# Altium schematic sheet Sheet1.SchDoc
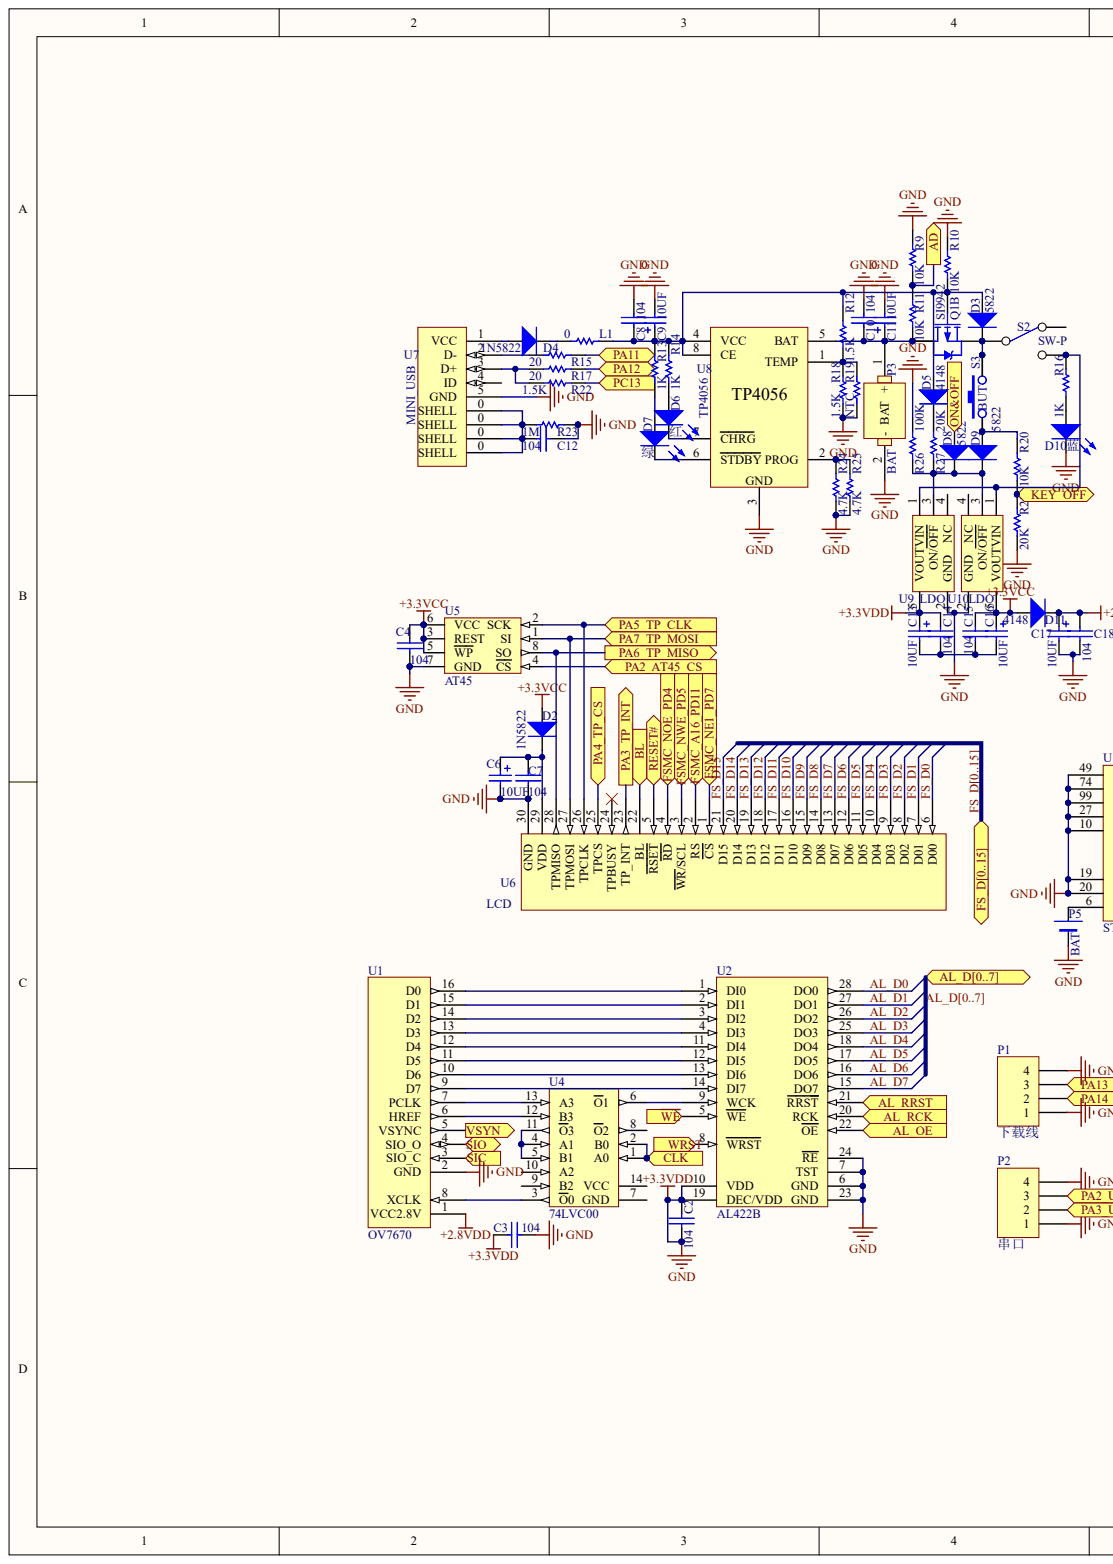
[diagram p0: Sheet1.SchDoc, left]
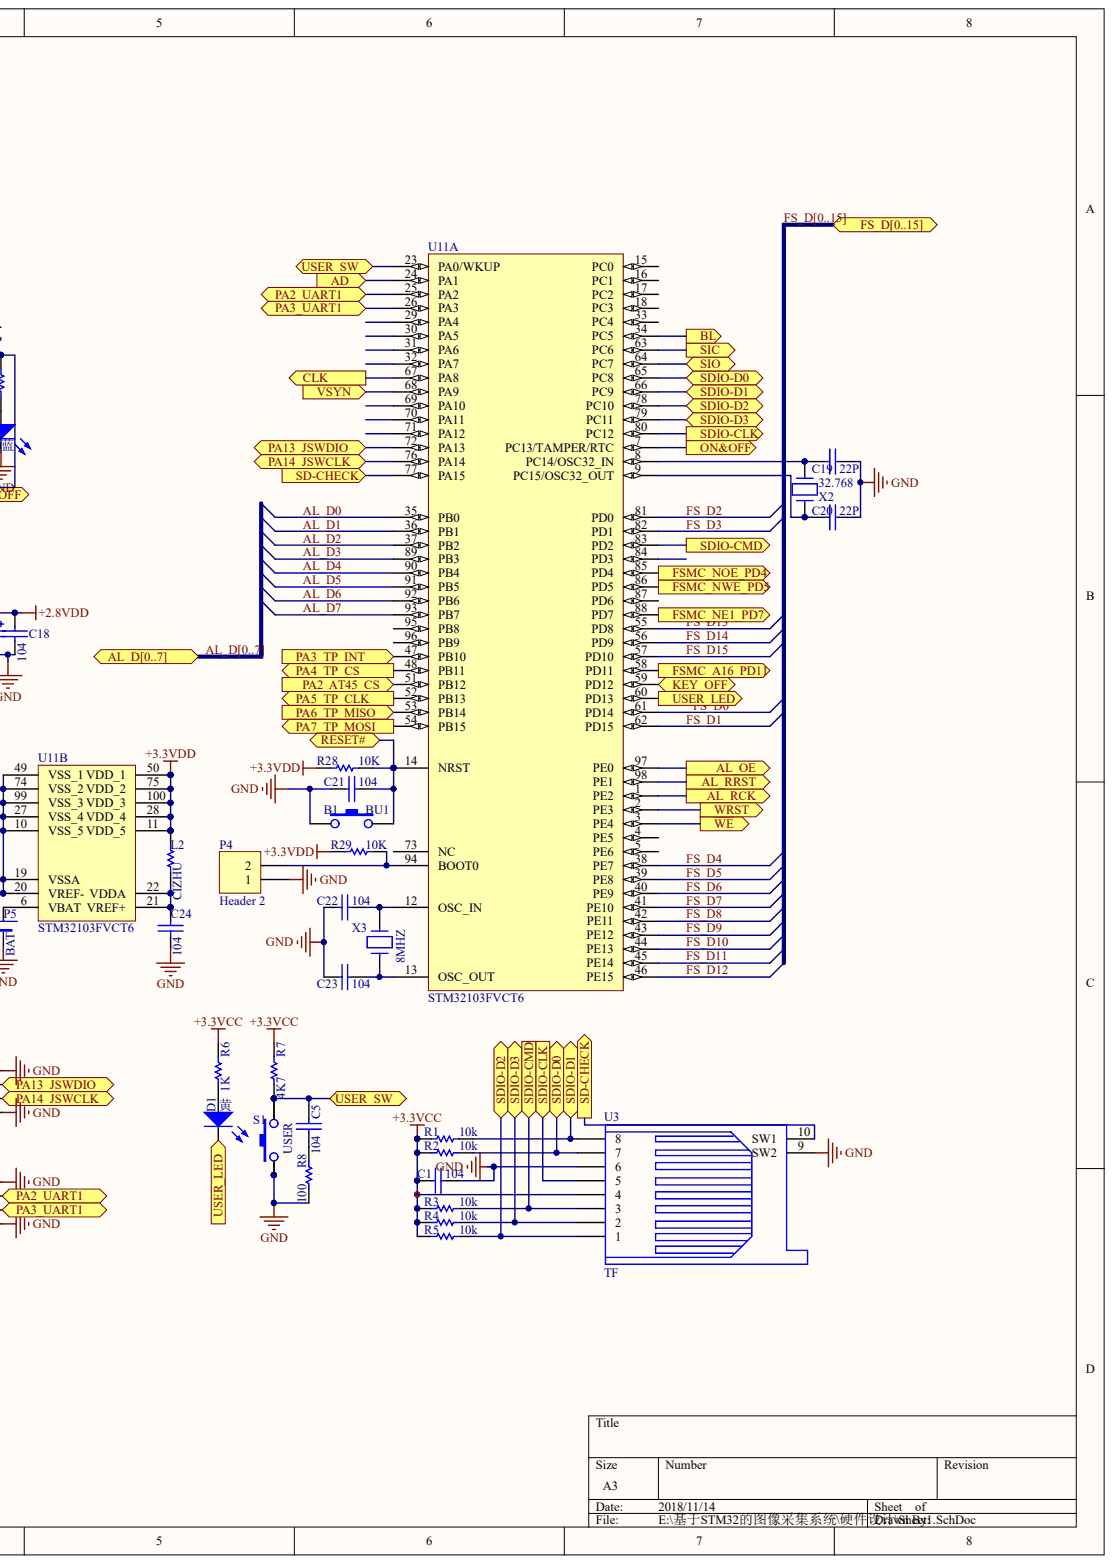
[diagram p1: Sheet1.SchDoc, right]

## Parts
B1  BU1
C1  104
C2  104
C3  104
C4  104
C5  104
C6  10UF
C7  104
C8  104
C9  10UF
C10  104
C11  10UF
C12  104
C13  10UF
C14  104
C15  10UF
C16  104
C17  10UF
C18  104
C19  22P
C20  22P
C21  104
C22  104
C23  104
C24  104
D1  黄
D2  1N5822
D3  5822
D4  1N5822
D5  4148
D6  红
D7  绿
D8  5822
D9  5822
D10  蓝
D11  4148
L1  0
L2  CIZHU
P1  下载线
P2  串口
P3  BAT
P4  Header 2
P5  BAT
Q1  SI9942  (part B)
R1  10k
R2  10k
R3  10k
R4  10k
R5  10k
R6  1K
R7  4K7
R8  100
R9  10K
R10  10K
R11  10K
R12  1.5K
R13  1K
R14  1K
R15  20
R16  1K
R17  20
R18  1.5K
R19  NTC
R20  10K
R21  20K
R22  1.5K
R23  1M
R24  4.7K
R25  4.7K
R26  100K
R27  20K
R28  10K
R29  10K
S1  USER
S2  SW-P
S3  BUT
U1  OV7670
U2  AL422B
U3  TF
U4  74LVC00
U5  AT45
U6  LCD
U7  MINI_USB
U8  TP4056
U9  LDO
U10  LDO
U11  STM32103FVCT6  (parts A,B)
X2  32.768
X3  8MHZ

## Ports
AD, AL_D0, AL_D1, AL_D2, AL_D3, AL_D4, AL_D5, AL_D6, AL_D7, AL_D[0..7], AL_OE, AL_RCK, AL_RRST, BL, CLK, FS_D0, FS_D1, FS_D2, FS_D3, FS_D4, FS_D5, FS_D6, FS_D7, FS_D8, FS_D9, FS_D10, FS_D11, FS_D12, FS_D13, FS_D14, FS_D15, FS_D[0..15], FSMC_A16_PD11, FSMC_NE1_PD7, FSMC_NOE_PD4, FSMC_NWE_PD5, KEY_OFF, ON&OFF, PA2_AT45_CS, PA2_UART1, PA3_TP_INT, PA3_UART1, PA4_TP_CS, PA5_TP_CLK, PA6_TP_MISO, PA7_TP_MOSI, PA11, PA12, PA13_JSWDIO, PA14_JSWCLK, PC13, RESET#, SD-CHECK, SDIO-CLK, SDIO-CMD, SDIO-D0, SDIO-D1, SDIO-D2, SDIO-D3, SIC, SIO, USER_LED, USER_SW, VSYN, WRST, ~{WE}

## Nets
+2.8VDD: C17.1 C18.2 D11.2 U1.1(VCC2.8V)
+3.3VCC: C1.1 C4.2 C15.1 C16.2 D2.1 D11.1 R1.2 R2.2 R3.2 R4.2 R5.2 R6.2 R7.2 U3.4 U5.3(REST) U5.5(WP) U5.6(VCC) U10.5(VOUT)
+3.3VDD: B1.1 C1.2 C2.1 C2.2 C3.1 C3.2 C4.1 C6.2 C7.1 C8.2 C9.2 C10.1 C11.2 C12.2 C13.1 C14.2 C17.2 C18.1 C19.2 C20.2 C21.1 C22.1 C23.1 C24.1 D10.2 L2.1 P1.1 P1.4 P2.1 P2.4 P3.2 P4.1 P5.2 R8.1 R9.1 R10.2 R18.2 R19.2 R21.2 R23.1 R24.2 R25.2 R26.2 R28.1 R29.1 S1.1 S1.2 U1.2(GND) U2.6(GND) U2.7(TST) U2.10(VDD) U2.19(DEC/VDD) U2.23(GND) U2.24(RE) U3.6 U3.9(SW2) U5.7(GND) U6.30(GND) U7.5(GND) U8.3 U9.2(GND) U9.5(VOUT) U10.2(GND) U11.10(VSS_5) U11.11(VDD_5) U11.19(VSSA) U11.20(VREF-) U11.27(VSS_4) U11.28(VDD_4) U11.49(VSS_1) U11.50(VDD_1) U11.74(VSS_2) U11.75(VDD_2) U11.99(VSS_3) U11.100(VDD_3)
AD: R9.2 R11.1 U11.24(PA1) ; port AD
AL_D0: U2.28(DO0) U11.35(PB0) ; port AL_D[0..7]  [also labelled AL_D]
AL_D1: U2.27(DO1) U11.36(PB1) ; port AL_D[0..7]  [also labelled AL_D]
AL_D2: U2.26(DO2) U11.37(PB2) ; port AL_D[0..7]  [also labelled AL_D]
AL_D3: U2.25(DO3) U11.89(PB3) ; port AL_D[0..7]  [also labelled AL_D]
AL_D4: U2.18(DO4) U11.90(PB4) ; port AL_D[0..7]  [also labelled AL_D]
AL_D5: U2.17(DO5) U11.91(PB5) ; port AL_D[0..7]  [also labelled AL_D]
AL_D6: U2.16(DO6) U11.92(PB6) ; port AL_D[0..7]  [also labelled AL_D]
AL_D7: U2.15(DO7) U11.93(PB7) ; port AL_D[0..7]  [also labelled AL_D]
AL_OE: U2.22(OE) U11.97(PE0) ; port AL_OE
AL_RCK: U2.20(RCK) U11.1(PE2) ; port AL_RCK
AL_RRST: U2.21(RRST) U11.98(PE1) ; port AL_RRST
BL: U6.22(BL) U11.34(PC5) ; port BL
CLK: U4.1(A0) U4.2(B0) U11.67(PA8) ; port CLK
FS_D0: U6.6(D00) U11.61(PD14) ; port FS_D[0..15]  [also labelled FS_D]
FS_D1: U6.7(D01) U11.62(PD15) ; port FS_D[0..15]  [also labelled FS_D]
FS_D2: U6.8(D02) U11.81(PD0) ; port FS_D[0..15]  [also labelled FS_D]
FS_D3: U6.9(D03) U11.82(PD1) ; port FS_D[0..15]  [also labelled FS_D]
FS_D4: U6.10(D04) U11.38(PE7) ; port FS_D[0..15]  [also labelled FS_D]
FS_D5: U6.11(D05) U11.39(PE8) ; port FS_D[0..15]  [also labelled FS_D]
FS_D6: U6.12(D06) U11.40(PE9) ; port FS_D[0..15]  [also labelled FS_D]
FS_D7: U6.13(D07) U11.41(PE10) ; port FS_D[0..15]  [also labelled FS_D]
FS_D8: U6.14(D08) U11.42(PE11) ; port FS_D[0..15]  [also labelled FS_D]
FS_D9: U6.15(D09) U11.43(PE12) ; port FS_D[0..15]  [also labelled FS_D]
FS_D10: U6.16(D10) U11.44(PE13) ; port FS_D[0..15]  [also labelled FS_D]
FS_D11: U6.17(D11) U11.45(PE14) ; port FS_D[0..15]  [also labelled FS_D]
FS_D12: U6.18(D12) U11.46(PE15) ; port FS_D[0..15]  [also labelled FS_D]
FS_D13: U6.19(D13) U11.55(PD8) ; port FS_D[0..15]  [also labelled FS_D]
FS_D14: U6.20(D14) U11.56(PD9) ; port FS_D[0..15]  [also labelled FS_D]
FS_D15: U6.21(D15) U11.57(PD10) ; port FS_D[0..15]  [also labelled FS_D]
FSMC_A16_PD11: U6.2(RS) U11.58(PD11) ; port FSMC_A16_PD11
FSMC_NE1_PD7: U6.1(CS) U11.88(PD7) ; port FSMC_NE1_PD7
FSMC_NOE_PD4: U6.4(RD) U11.85(PD4) ; port FSMC_NOE_PD4
FSMC_NWE_PD5: U6.3(WR/SCL) U11.86(PD5) ; port FSMC_NWE_PD5
KEY_OFF: R20.2 R21.1 U11.59(PD12) ; port KEY_OFF
ON&OFF: D8.1 U11.7(PC13/TAMPER/RTC) ; port ON&OFF
PA2_AT45_CS: U5.4(CS) U11.51(PB12) ; port PA2_AT45_CS
PA2_UART1: P2.3 U11.25(PA2) ; port PA2_UART1
PA3_TP_INT: U6.23(TP_INT) U11.47(PB10) ; port PA3_TP_INT
PA3_UART1: P2.2 U11.26(PA3) ; port PA3_UART1
PA4_TP_CS: U6.25(TPCS) U11.48(PB11) ; port PA4_TP_CS
PA5_TP_CLK: U5.2(SCK) U6.26(TPCLK) U11.52(PB13) ; port PA5_TP_CLK
PA6_TP_MISO: U5.8(SO) U6.28(TPMISO) U11.53(PB14) ; port PA6_TP_MISO
PA7_TP_MOSI: U5.1(SI) U6.27(TPMOSI) U11.54(PB15) ; port PA7_TP_MOSI
PA11: R15.1 ; port PA11
PA12: R17.1 ; port PA12
PA13_JSWDIO: P1.3 U11.72(PA13) ; port PA13_JSWDIO
PA14_JSWCLK: P1.2 U11.76(PA14) ; port PA14_JSWCLK
PC13: R22.1 ; port PC13
RESET#: B1.2 C21.2 R28.2 U6.5(RSET) U11.14(NRST) ; port RESET#
SD-CHECK: U3.10(SW1) U11.77(PA15) ; port SD-CHECK
SDIO-CLK: U3.5 U11.80(PC12) ; port SDIO-CLK
SDIO-CMD: R3.1 U3.3 U11.83(PD2) ; port SDIO-CMD
SDIO-D0: R2.1 U3.7 U11.65(PC8) ; port SDIO-D0
SDIO-D1: R1.1 U3.8 U11.66(PC9) ; port SDIO-D1
SDIO-D2: R5.1 U3.1 U11.78(PC10) ; port SDIO-D2
SDIO-D3: R4.1 U3.2 U11.79(PC11) ; port SDIO-D3
SIC: U1.3(SIO_C) U11.63(PC6) ; port SIC
SIO: U1.4(SIO_O) U11.64(PC7) ; port SIO
USER_LED: D1.2 U11.60(PD13) ; port USER_LED
USER_SW: C5.1 R7.1 S1.3 S1.4 U11.23(PA0/WKUP) ; port USER_SW
VSYN: U1.5(VSYNC) U11.68(PA9) ; port VSYN
~{WE}: U2.5(WE) U11.3(PE4) ; port ~{WE}
WRST: U2.8(WRST) U11.2(PE3) ; port WRST
NetC5_2: C5.2 R8.2
NetC6_1: C6.1 C7.2 D2.2 U6.29(VDD)
NetC8_1: C8.1 C9.1 D3.1 D5.1 L1.1 Q1.4 R10.1 R12.1 R13.1 R14.1 U8.4(VCC) U8.8(CE)
NetC10_2: C10.2 C11.1 P3.1 Q1.5 Q1.6 R11.2 U8.5(BAT)
NetC12_1: C12.1 R23.2 U7.0(SHELL)
NetC13_2: C13.2 C14.1 C15.2 C16.1
NetC19_1: C19.1 U11.8(PC14/OSC32_IN) X2.2
NetC20_1: C20.1 U11.9(PC15/OSC32_OUT) X2.1
NetC22_2: C22.2 U11.12(OSC_IN) X3.2
NetC23_2: C23.2 U11.13(OSC_OUT) X3.1
NetC24_2: C24.2 L2.2 U11.21(VREF+) U11.22(VDDA)
NetD1_1: D1.1 R6.1
NetD3_2: D3.2 Q1.3 S2.1 S3.3 S3.4
NetD4_1: D4.1 U7.1(VCC)
NetD4_2: D4.2 L1.2
NetD5_2: D5.2 R27.2
NetD6_1: D6.1 R14.2
NetD6_2: D6.2 U8.7(CHRG)
NetD7_1: D7.1 R13.2
NetD7_2: D7.2 U8.6(STDBY)
NetD8_2: D8.2 D9.2 R26.1 R27.1 U9.3(ON/OFF) U10.3(ON/OFF)
NetD9_1: D9.1 R20.1 S3.1 S3.2
NetD10_1: D10.1 R16.1
NetP4_2: P4.2 R29.2 U11.94(BOOT0)
NetP5_1: P5.1 U11.6(VBAT)
NetR12_2: R12.2 R18.1 R19.1 U8.1(TEMP)
NetR15_2: R15.2 U7.2(D-)
NetR16_2: R16.2 S2.3 U9.1(VIN) U10.1(VIN)
NetR17_2: R17.2 R22.2 U7.3(D+)
NetR24_1: R24.1 R25.1 U8.2(PROG)
NetU1_6: U1.6(HREF) U4.12(B3)
NetU1_7: U1.7(PCLK) U4.13(A3)
NetU1_8: U1.8(XCLK) U4.3(O0)
NetU1_9: U1.9(D7) U2.14(DI7)
NetU1_10: U1.10(D6) U2.13(DI6)
NetU1_11: U1.11(D5) U2.12(DI5)
NetU1_12: U1.12(D4) U2.11(DI4)
NetU1_13: U1.13(D3) U2.4(DI3)
NetU1_14: U1.14(D2) U2.3(DI2)
NetU1_15: U1.15(D1) U2.2(DI1)
NetU1_16: U1.16(D0) U2.1(DI0)
NetU2_9: U2.9(WCK) U4.6(O1)
NetU4_4: U4.4(A1) U4.5(B1) U4.11(O3)

Not connected: S2 2; U4 7(GND) 8(O2) 9(B2) 10(A2) 14(VCC); U6 24(TPBUSY); U7 4(ID); U9 4(NC); U10 4(NC); U11 4(PE5) 5(PE6) 15(PC0) 16(PC1) 17(PC2) 18(PC3) 29(PA4) 30(PA5) 31(PA6) 32(PA7) 33(PC4) 69(PA10) 70(PA11) 71(PA12) 73(NC) 84(PD3) 87(PD6) 95(PB8) 96(PB9)

## Library data of the parts
B1 C1-C24 D1-D11 L1 L2 P1-P5 Q1 R1-R29 S1-S3 U1-U11 X2 X3 = (no symbol name)
  P1 P2 P4: LatestRevisionDate: 17-Jul-2002; LatestRevisionNote: Re-released for DXP Platform.; Publisher: Altium Limited
